# Altium schematic sheet MSXIV_ConnectorTestingBoard.SchDoc
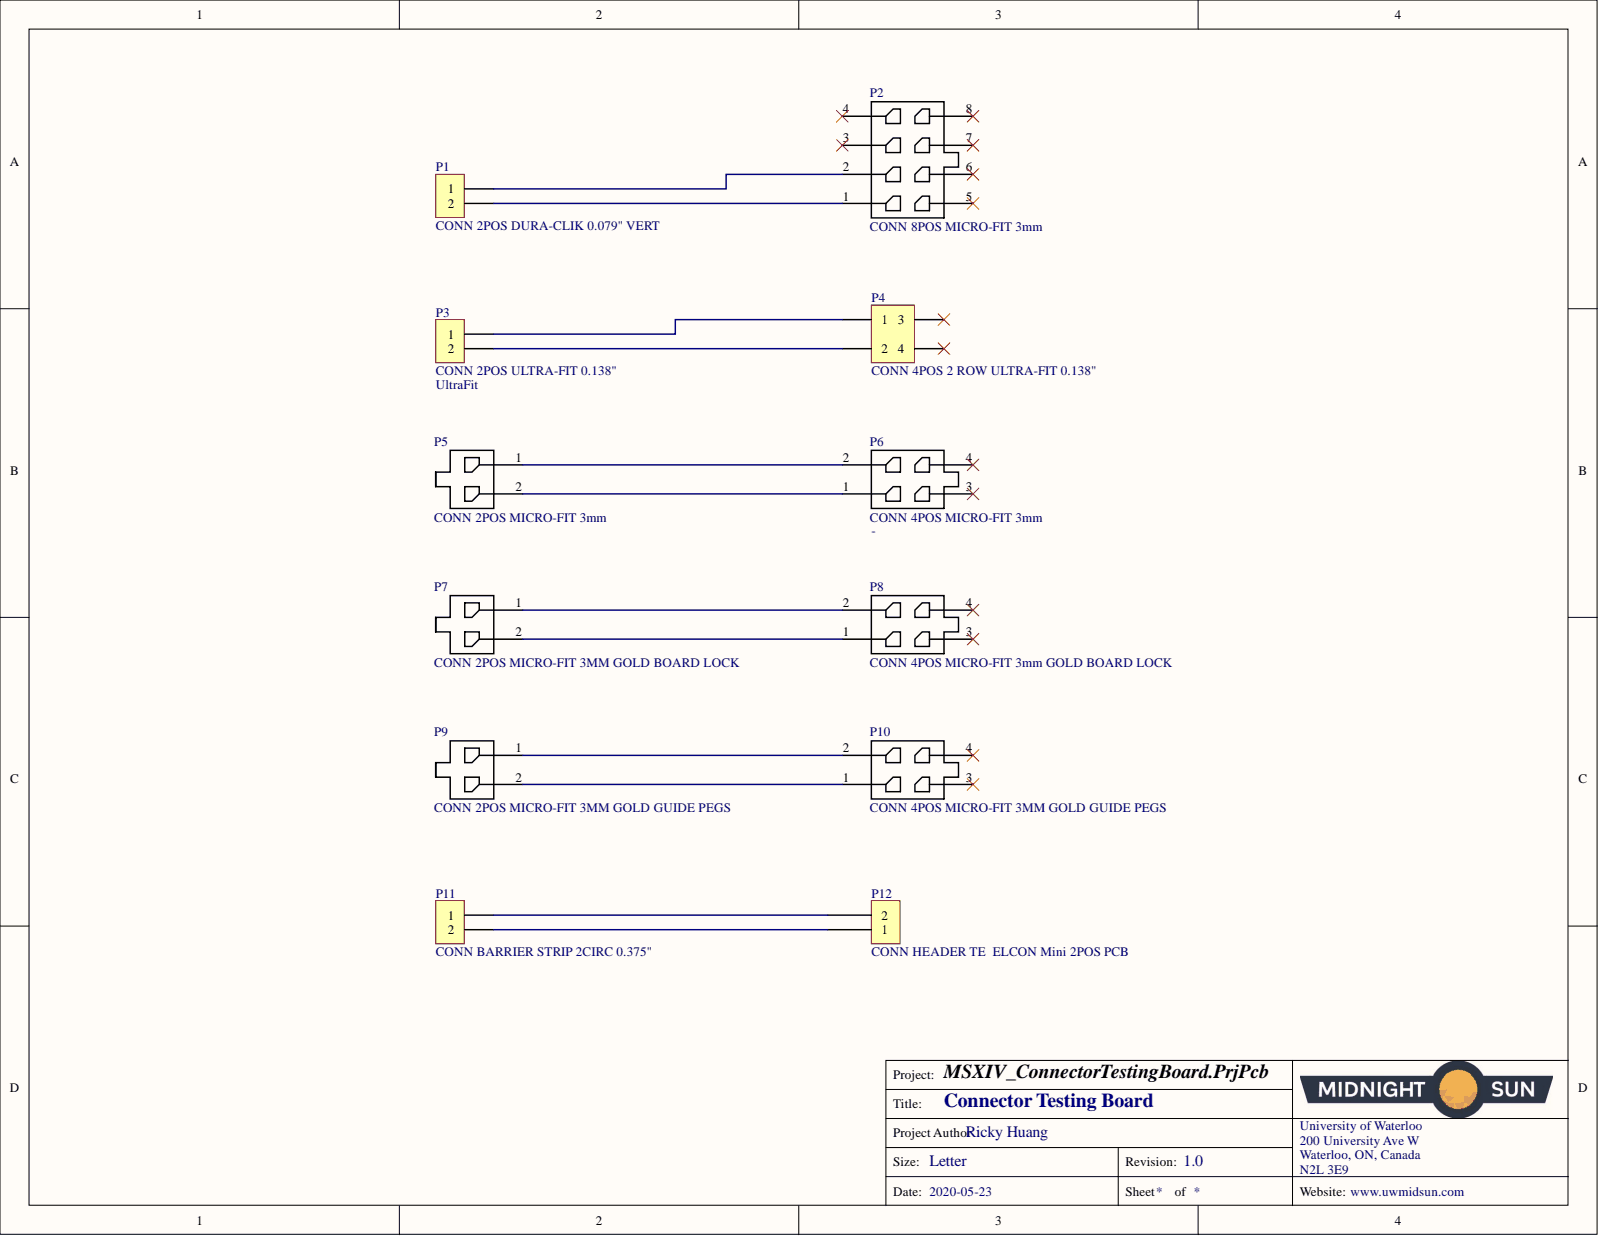
[diagram p0: MSXIV_ConnectorTestingBoard.SchDoc]

## Parts
P1  CONN 2POS DURA-CLIK 0.079" VERT  [CONN, 2POS Dura-Click Vertical]
P2  CONN 8POS MICRO-FIT 3mm  [CONN, 8POS MICRO-FIT]
P3  CONN 2POS ULTRA-FIT 0.138"  Series: UltraFit  [CONN, 2POS ULTRA-FIT]
P4  CONN 4POS 2 ROW ULTRA-FIT 0.138"  [CONN, 4POS 2 ROW ULTRA-FIT]
P5  CONN 2POS MICRO-FIT 3mm  [CONN 2POS MICRO-FIT 3mm-Footprint-1]
P6  CONN 4POS MICRO-FIT 3mm  [CONN 4POS MICROFIT (2x2)]
P7  CONN 2POS MICRO-FIT 3MM GOLD BOARD LOCK  [CONN HEADER VERT 2POS 3MM GOLD BOARD LOCK-Footprint-1]
P8  CONN 4POS MICRO-FIT 3mm GOLD BOARD LOCK  [CONN 4POS MICRO-FIT 3mm GOLD BOARD LOCK-Footprint-1]
P9  CONN 2POS MICRO-FIT 3MM GOLD GUIDE PEGS  [CONN 2POS MICRO-FIT 3MM GOLD GUIDE PEGS-Footprint-1]
P10  CONN 4POS MICRO-FIT 3MM GOLD GUIDE PEGS  [CONN 4POS MICRO-FIT 3MM GOLD GUIDE PEGS-Footprint-1]
P11  CONN BARRIER STRIP 2CIRC 0.375"  [TE_3-1437652-9]
P12  CONN HEADER TE  ELCON Mini 2POS PCB  [CONN HEADER TE  ELCON Mini 2POS PCB-Footprint-1]

## Nets
NetP1_1: P1.1 P2.2
NetP1_2: P1.2 P2.1
NetP3_1: P3.1 P4.1
NetP3_2: P3.2 P4.2
NetP5_1: P5.1 P6.2
NetP5_2: P5.2 P6.1
NetP7_1: P7.1 P8.2
NetP7_2: P7.2 P8.1
NetP9_1: P9.1 P10.2
NetP9_2: P9.2 P10.1
NetP11_1: P11.1 P12.2
NetP11_2: P11.2 P12.1

Not connected: P2 3 4 5 6 7 8; P4 3 4; P6 3 4; P8 3 4; P10 3 4

## Library data of the parts
P1 = CMP-007-0025-1: 2 Positions Header, Shrouded Connector 0.079" (2.00mm) Surface Mount, Vertical Tin; Manufacturer: Molex, LLC; Manufacturer Part Number: 5600200220; Mated Stacking Heights: 9.3mm; Number of Positions: 2; Number of Positions Loaded: All; Shrouding: Shrouded - 4 Wall; Style: Board to Cable/Wire; Applications: Automotive, Industrial; Component Kind: Standard; ComponentLink1Description: http://www.molex.com/pdm_docs/sd/5600200220_sd.pdf; ComponentLink1URL: http://www.molex.com/pdm_docs/sd/5600200220_sd.pdf; Connector Type: Header; Contact Finish - Mating: Tin; Contact Finish - Post: Tin; Contact Finish Thickness - Mating: -; Contact Finish Thickness - Post: -; Contact Length - Mating: -; Contact Length - Post: -; Contact Material: Copper Alloy; Contact Shape: Square; Contact Type: Male Pin; Current Rating: -; Design Item ID: CONN 2POS DURA-CLIK 0.079" VERT; Fastening Type: Latch Lock; Features: Solder Retention; Ingress Protection: -; Insulation Color: Natural; Insulation Height: 0.315" (8.00mm); Insulation Material: Polyamide (PA), Nylon; Material Flammability Rating: -; Mounting Type: Surface Mount; Number of Rows: 1; Operating Temperature: -; Overall Contact Length: -; Packaging: Cut Tape (CT); Part Status: Active; Pitch - Mating: 0.079" (2.00mm); Pricing 1: 1=1.16, 10=1.012, 25=0.952, 50=0.9122, 100=0.8727, 250=0.79336 (CAD); RoHS: RoHS Compliant; Row Spacing - Mating: -; Stock 1: 11912; Termination: Solder; Voltage Rating: -; Library Name: University of Waterloo - Solar Car Team; Source: Schematic Diagrams.SchLib
P2 = CMP-007-0004-1: Connector Header Through Hole 6 position 0.118" (3.00mm); Manufacturer: Molex, LLC; Manufacturer Part Number: 0430450827; Contact Length - Post: 0.125" (3.18mm); Material Flammability Rating: UL94 V-0; Number of Positions: 6; Number of Positions Loaded: All; Shrouding: Shrouded - 4 Wall; Style: Board to Cable/Wire; Applications: General Purpose, Medical, Military, Telecommunications; Component Kind: Standard; ComponentLink1Description: https://www.molex.com/pdm_docs/sd/430450627_sd.pdf; ComponentLink1URL: https://www.molex.com/pdm_docs/sd/430450627_sd.pdf; ComponentLink2Description: https://www.molex.com/pdm_docs/sd/430450827_sd.pdf; ComponentLink2URL: https://www.molex.com/pdm_docs/sd/430450827_sd.pdf; Connector Type: Header; Contact Finish - Mating: Tin; Contact Finish - Post: Tin; Contact Finish Thickness - Mating: 60.0µin (1.52µm); Contact Finish Thickness - Post: -; Contact Length - Mating: -; Contact Material: Brass; Contact Shape: Square; Contact Type: Male Pin; Current Rating: -; Design Item ID: CONN 8POS MICRO-FIT 3mm; Fastening Type: Locking Ramp; Features: Board Guide; Ingress Protection: -; Insulation Color: Black; Insulation Height: 0.390" (9.91mm); Insulation Material: Liquid Crystal Polymer (LCP), Glass Filled; Mated Stacking Heights: -; Mounting Type: Through Hole; Number of Rows: 2; Operating Temperature: -; Overall Contact Length: -; Packaging: Tray; Part Status: Active; Pitch - Mating: 0.118" (3.00mm); Pricing 1: 1=2.69, 10=2.445, 25=2.2964, 50=2.1964, 100=2.0965, 250=1.8968, 500=1.74704, 1440=1.49746, 2880=1.39763 (CAD); RoHS: RoHS Compliant; Row Spacing - Mating: 0.118" (3.00mm); Stock 1: 5553; Termination: Solder; Voltage Rating: -; Library Name: University of Waterloo - Solar Car Team; Source: Schematic Diagrams.SchLib
P3 = CMP-007-0011-1: 2 Positions Header, Shrouded Connector 0.138" (3.50mm) Through Hole Gold; Manufacturer 1: Molex, LLC; Manufacturer Part Number 1: 1722861302; Contact Length - Post: 0.126" (3.20mm); Mated Stacking Heights: 26.99mm; Material Flammability Rating: UL94 V-0; Number of Positions: 2; Number of Positions Loaded: All; Shrouding: Shrouded - 4 Wall; Style: Board to Board or Cable; Voltage: 400V; Component Kind: Standard; ComponentLink1Description: http://www.molex.com/pdm_docs/sd/1722861302_sd.pdf; ComponentLink1URL: http://www.molex.com/pdm_docs/sd/1722861302_sd.pdf; Connector Type: Header; Contact Finish - Mating: Gold; Contact Finish - Post: Tin; Contact Finish Thickness - Mating: 30µin (0.76µm); Contact Finish Thickness - Post: 60µin (1.52µm); Contact Length - Mating: -; Contact Material: Copper Alloy; Contact Shape: Square; Contact Type: Male Pin; Current Rating: -; Design Item ID: CONN 2POS ULTRA-FIT 0.138"; Fastening Type: Latch Holder; Ingress Protection: -; Insulation Color: Black; Insulation Height: 0.465" (11.80mm); Insulation Material: Liquid Crystal Polymer (LCP); Mounting Type: Through Hole; Number of Rows: 1; Operating Temperature: -40°C ~ 120°C; Overall Contact Length: -; Packaging: Tray; Part Status: Active; Pitch - Mating: 0.138" (3.50mm); Row Spacing - Mating: -; Termination: Kinked Pin, Solder; Library Name: University of Waterloo - Solar Car Team; Source: Schematic Diagrams.SchLib
P4 = CMP-007-0027-2: Connector Header Through Hole 4 position 0.138" (3.50mm); Manufacturer 1: Molex; Manufacturer Part Number 1: 1722991104; Connector Type: Male Pin; Description 1: ULTRA-FIT HDR VT DUAL F BLK 04CKT TIN; Number of Positions: 4; Pitch: 0.138inch; Row Spacing: 0.181inch; Colour: Black; Component Kind: Standard; ComponentLink1Description: http://www.literature.molex.com/SQLImages/kelmscott/Molex/PDF_Images/987651-1008.pdf; ComponentLink1URL: http://www.literature.molex.com/SQLImages/kelmscott/Molex/PDF_Images/987651-1008.pdf; Contact Plating: Tin; Design Item ID: CONN 4POS 2 ROW ULTRA-FIT 0.138"; Fastening Type: Latch; Mount: Through Hole; Number of Rows: 2; Packaging: Tray; Pricing 1: 1=0.86, 10=0.753, 100=0.6494, 500=0.53136, 1000=0.47232, 5000=0.41328, 10000=0.39262 (USD); Stock 1: 958; Termination: Solder; Library Name: University of Waterloo - Solar Car Team; Source: Schematic Diagrams.SchLib
P5 = CMP-002-00074-8: Conn Power HDR 2 POS 3mm Solder ST Thru-Hole 2 Terminal 1 Port Tray; Connector Type: Male Pin; Contact Resistance: 10mR; Current Rating: 5A; Gender: Male; Glow Wire Compliant: Compliant; Max Voltage Rating (AC): 250V; Max Voltage Rating (DC): 250.25kV; Number of Circuits: 2; Voltage Rating: 600V; Component Kind: Standard; Contact Material: Brass,Tin; Contact Plating: Tin; Depth: 4.57mm; Flammability Rating: UL94 V-0; Halogen Free: Low Halogen; Height: 9.9mm; Housing Color: Black; Housing Material: Thermoplastic; Lead Free: Lead Free; Length: 9.65mm; Max Current Rating: 5A; Max Operating Temperature: 105°C; Min Operating Temperature: -40°C; Mount: Through Hole; Number of Contacts: 2; Number of Positions: 2; Number of Rows: 1; Orientation: Vertical; Package Quantity: 3264; Packaging: Tray; Pins: 2; Pitch: 3mm; Radiation Hardening: No; REACH SVHC: Unknown; Termination: Solder; Voltage Rating (DC): 250V; Library Name: University of Waterloo - Solar Car Team
P6 = CMP-003-0105-1: Micro-Fit 3.0 Vertical Header, 3.00mm Pitch, Dual Row, 4 Circuits, with PCB Polarizing Peg, Tin, Glow-Wire Capable, Black; Manufacturer: Molex, LLC; Manufacturer Part Number: 430450427; Contact Length - Post: 0.125" (3.18mm); Contact Resistance: 10mR; Material Flammability Rating: UL94 V-0; Number of Contacts: 4; Number of Ports: 1; Number of Positions: 6; Number of Positions Loaded: All; Pitch - Mating: 0.118" (3.00mm); Row Spacing: 0.118inch; Shrouding: Shrouded - 4 Wall; Style: Board to Cable/Wire; Applications: General Purpose, Medical, Military, Telecommunications; Color: Black; Component Kind: Standard; ComponentLink1Description: http://www.molex.com/webdocs/datasheets/pdf/en-us/0430450427_PCB_HEADERS.pdf; ComponentLink1URL: http://www.molex.com/webdocs/datasheets/pdf/en-us/0430450427_PCB_HEADERS.pdf; Connector Type: Header; Contact Finish - Mating: Tin; Contact Finish - Post: Tin; Contact Finish Thickness - Mating: 60.0µin (1.52µm); Contact Finish Thickness - Post: -; Contact Length - Mating: -; Contact Material: Brass; Contact Plating: Tin; Contact Shape: Square; Contact Type: Male Pin; Current Rating: -; Depth: 8.77mm; Design Item ID: CONN 4POS MICRO-FIT 3mm; Fastening Type: Locking Ramp; Features: Board Guide; Housing Color: Black; Housing Material: Polymer; Ingress Protection: -; Insulation Color: Black; Insulation Height: 0.390" (9.91mm); Insulation Material: Liquid Crystal Polymer (LCP), Glass Filled; Length: 10.15mm; Mated Stacking Heights: -; Max Operating Temperature: 105°C; Min Operating Temperature: -40°C; Mount: Through Hole; Mounting Type: Through Hole; Number of Rows: 2; Number of Terminals: 4; Operating Temperature: -; Orientation: Straight; Overall Contact Length: -; Package Quantity: 2232; Packaging: Tray; Part Status: Active; Pitch: 3mm; RoHS: RoHS Compliant; Row Spacing - Mating: 0.118" (3.00mm); Termination: Solder; Voltage Rating: -; Library Name: University of Waterloo - Solar Car Team; Source: Schematic Diagrams.SchLib
P7 = CMP-002-00072-6: Conn Power HDR 2 POS 3mm Solder ST Thru-Hole 2 Terminal 1 Port Micro-Fit 3.0™ Tray; Connector Type: Male Pin; Number of Contacts: 2; Number of Ports: 1; Color: Black; Component Kind: Standard; Contact Material: Brass; Contact Plating: Gold,GXT; Depth: 5.57mm; Fastening Type: Ramp; Housing Material: Thermoplastic; Length: 9.65mm; Max Operating Temperature: 105°C; Min Operating Temperature: -40°C; Mount: Through Hole; Number of Positions: 2; Number of Rows: 1; Number of Terminals: 2; Orientation: Straight; Package Quantity: 3264; Packaging: Tray; Pitch: 3mm; Termination: Solder; Library Name: University of Waterloo - Solar Car Team
P8 = CMP-002-00073-4: CONN HEADER VERT 4POS 3MM; Connector Type: Male Pin; Contact Resistance: 10mR; Number of Contacts: 4; Number of Ports: 1; Row Spacing: 0.118inch; Color: Black; Component Kind: Standard; Contact Material: Brass; Contact Plating: Gold; Depth: 8.77mm; Fastening Type: Latch; Housing Color: Black; Housing Material: Polymer; Lead Free: Lead Free; Length: 10.15mm; Max Operating Temperature: 105°C; Min Operating Temperature: -40°C; Mount: Through Hole; Number of Positions: 4; Number of Rows: 2; Number of Terminals: 4; Orientation: Straight; Packaging: Tray; Pitch: 3mm; Termination: Solder; Library Name: University of Waterloo - Solar Car Team
P9 = CMP-002-00075-6: Conn; Rect; Header; 2 Cir; PCB; MicroFit 3.0; Vertical; Single; SMT; w/Peg; Gold; Connector Type: Male Pin; Max Voltage Rating (AC): 250V; Number of Contacts: 2; Number of Ports: 1; Color: Black; Component Kind: Standard; Contact Material: Brass; Contact Plating: Gold; Depth: 5.57mm; Fastening Type: Ramp; Housing Material: Polymer; Length: 9.65mm; Max Operating Temperature: 105°C; Min Operating Temperature: -40°C; Mount: Through Hole; Number of Positions: 2; Number of Rows: 1; Number of Terminals: 2; Orientation: Straight; Packaging: Tray; Pitch: 3mm; Termination: Solder; Library Name: University of Waterloo - Solar Car Team
P10 = CMP-002-00076-4: Conn Power HDR 4 POS 3mm Solder ST Thru-Hole 4 Terminal 1 Port Micro-Fit 3.0™ Tray; Connector Type: Male Pin; Contact Resistance: 10mR; Max Voltage Rating (AC): 250V; Number of Contacts: 4; Number of Ports: 1; Row Spacing: 0.118inch; Color: Black; Component Kind: Standard; Contact Material: Brass; Contact Plating: Gold; Depth: 8.77mm; Fastening Type: Ramp; Housing Color: Black; Housing Material: Polymer; Length: 10.15mm; Max Operating Temperature: 105°C; Min Operating Temperature: -40°C; Mount: Through Hole; Number of Positions: 4; Number of Rows: 2; Number of Terminals: 4; Orientation: Straight; Packaging: Tray; Pitch: 3mm; Termination: Solder; Library Name: University of Waterloo - Solar Car Team
P11 = CMP-007-0031-3: Conn Power HDR 5 POS 3mm Solder ST Thru-Hole 5 Terminal 1 Port Micro-Fit 3.0™ Tray; Manufacturer: TE Connectivity; Manufacturer Part Number: 6PCV-02-006; Connector Type: Male Pin; Contact Resistance: 30mR; Current Rating: 5A; Max Current Rating: 25A; Max Voltage Rating (AC): 250V; Mounting Ears: No (Without); Number of Circuits: 2; Number of Levels: 1; Pitch: 9.53mm; Voltage: 300V; Wire/Cable Gauge: 22AWG; Wire Gauge (Max): 12AWG; Case/Package: 270; Color: Black; Component Kind: Standard; ComponentLink1Description: https://datasheet.ciiva.com/7978/1650932-7978603.pdf?src-supplier=Element14; ComponentLink1URL: https://datasheet.ciiva.com/7978/1650932-7978603.pdf?src-supplier=Element14; Contact Material: Copper Alloy; Contact Plating: Tin; Depth: 5.57mm; Design Item ID: CONN BARRIER STRIP 2CIRC 0.375"; ELV: Compliant; Fastening Type: Ramp; Flammability Rating: UL94 V-0; Height: 0.625inch; Housing Color: Black; Housing Material: Thermoplastic; Length: 0.811inch; Max Operating Temperature: 105°C; Min Operating Temperature: -40°C; Mount: Through Hole; Mounting Insert: No (Without); Number of Contacts: 2; Number of Ports: 1; Number of Positions: 2; Number of Rows: 1; Number of Terminals: 5; Orientation: Straight; Packaging: Bulk; Pricing 1: 1=1.63000, 10=1.14400, 25=1.06680, 50=0.96820, 100=0.96400, 250=0.81404, 500=0.77122, 1000=0.68552, 5000=0.55699 (USD); Pricing 2: 1=1.14000, 10=0.99900, 100=0.86150, 500=0.70488, 1000=0.62656, 5000=0.54824, 10000=0.52083 (USD); REACH SVHC: Unknown; RoHS: Yes; Stock 1: 8088; Stock 2: 6059; Terminal Material: Copper Alloy; Termination: Solder; Voltage Rating: 300V; Width: 0.7inch; Wire Gauge (Min): 22AWG; Library Name: University of Waterloo - Solar Car Team; Source: Schematic Diagrams.SchLib
P12 = CMP-002-00071-4: CONN HEADER 2POS PCB; Circuit Application: Power; Connector System: Wire-to-Board; Connector Type: Pin; Contact Current Rating: 40A; Contact Retention: Yes (With); Current Rating: 45A; Gender: Male; Number of Contacts: 2; Number of Ports: 1; PCB Mounting Orientation: Vertical; Pitch: 7.8mm; Sealable: No (Without); Component Kind: Standard; Contact Gender: Male; Contact Material: Copper Alloy,Copper; Contact Plating: Silver; Depth: 10.2mm; Flammability Rating: UL94 V-0; Housing Color: Black; Housing Material: Polymer; Length: 18.9mm; Max Operating Temperature: 125°C; Min Operating Temperature: -40°C; Mount: Through Hole; Number of Positions: 2; Number of Power Positions: 2; Number of Rows: 1; Number of Terminals: 4; Orientation: Straight; Package Quantity: 150; Packaging: Tape and Reel; Radiation Hardening: No; REACH SVHC: Unknown; Row Spacing: 7.8mm; Termination: Through Hole; Library Name: University of Waterloo - Solar Car Team
